# Altium schematic sheet Power_Supply2.SchDoc(Power_Supply2)
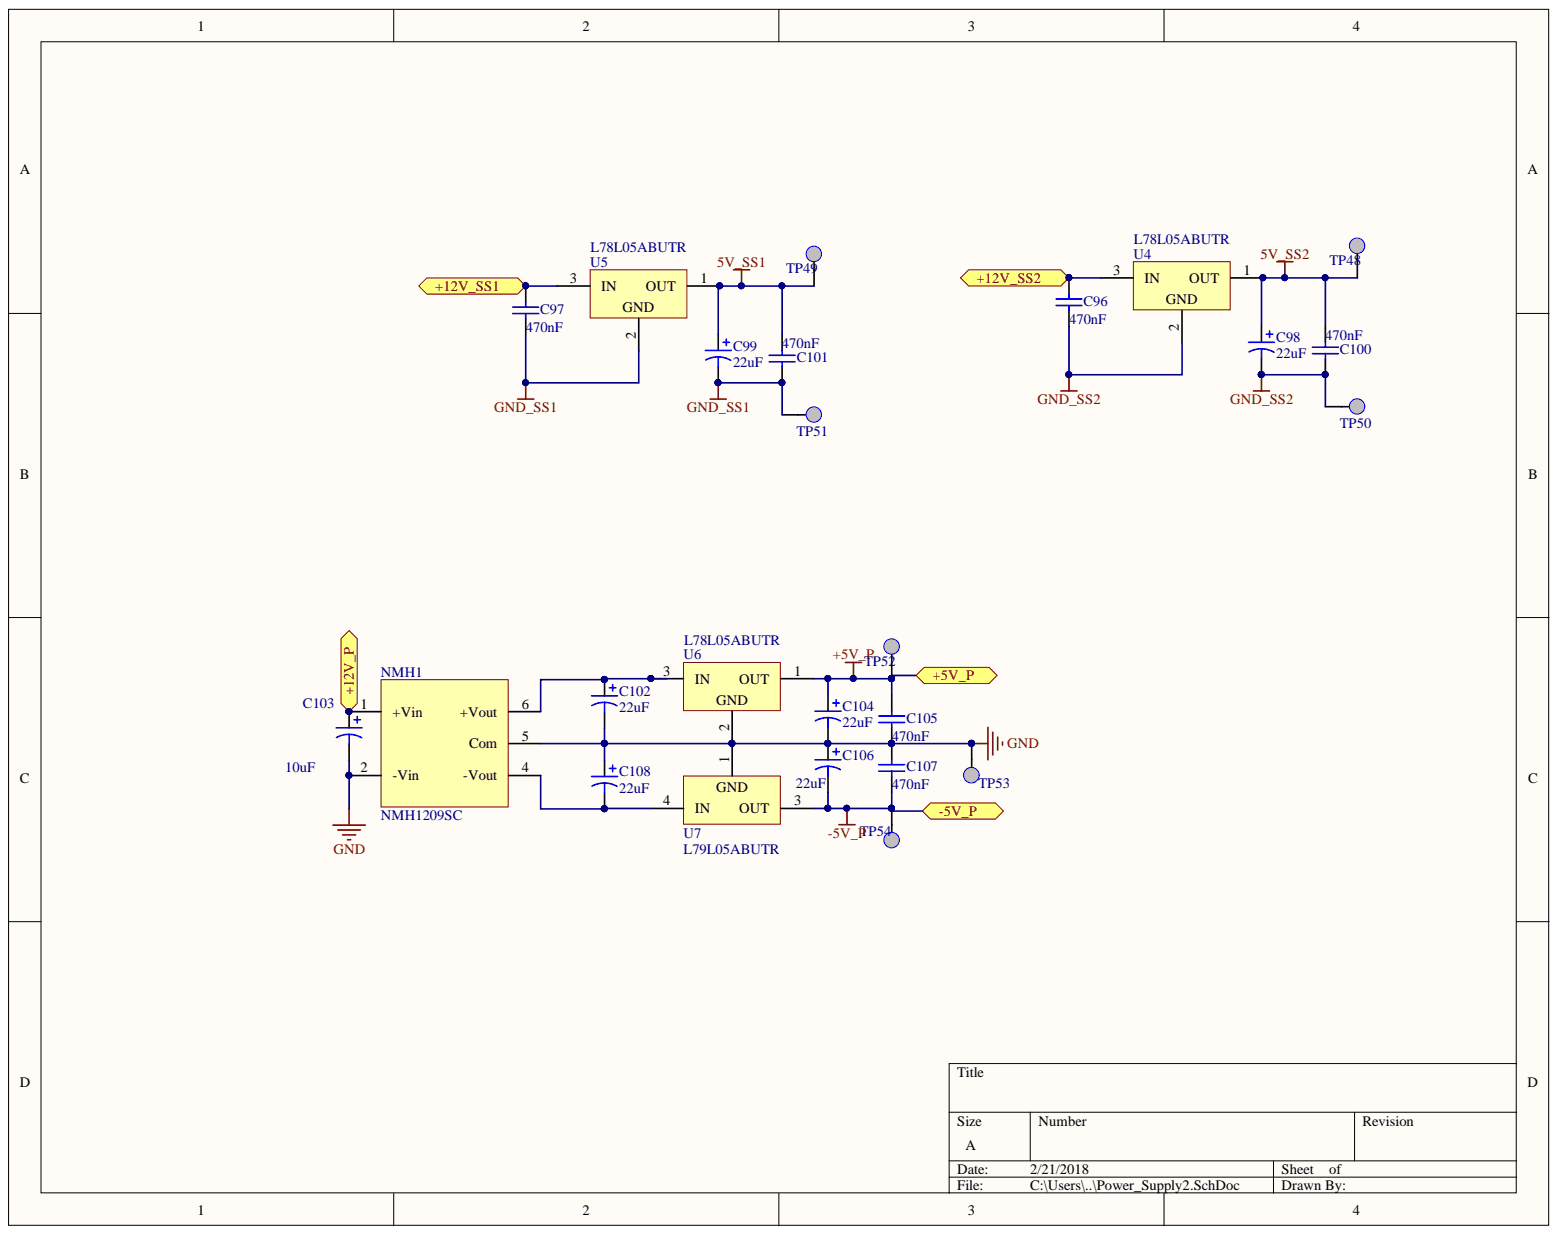
[diagram p0: Power_Supply2.SchDoc]

## Parts
C96  value 470nF  [Capacitor 0805]  (hidden comment: Cap)
C97  value 470nF  [Capacitor 0805]  (hidden comment: Cap)
C98  value 22uF  [Cap Tantal 3216]  (hidden comment: Tantalium/T520A226M006ATE090)
C99  value 22uF  [Cap Tantal 3216]  (hidden comment: Tantalium/T520A226M006ATE090)
C100  value 470nF  [Capacitor 0805]  (hidden comment: Cap)
C101  value 470nF  [Capacitor 0805]  (hidden comment: Cap)
C102  value 22uF  [Cap tantal 22u-25V]  (hidden comment: Tantalium)
C103  value 10uF  [CAP-10u-16V]  (hidden comment: electrolytic)
C104  value 22uF  [Cap Tantal 3216]  (hidden comment: Tantalium/T520A226M006ATE090)
C105  value 470nF  [Capacitor 0805]  (hidden comment: Cap)
C106  value 22uF  [Cap Tantal 3216]  (hidden comment: Tantalium/T520A226M006ATE090)
C107  value 470nF  [Capacitor 0805]  (hidden comment: Cap)
C108  value 22uF  [Cap tantal 22u-25V]  (hidden comment: Tantalium)
NMH1  NMH1209SC  [NMH1209SC]
TP48  Test point  [Test Point]  (value not drawn)
TP49  Test point  [Test Point]  (value not drawn)
TP50  Test point  [Test Point]  (value not drawn)
TP51  Test point  [Test Point]  (value not drawn)
TP52  Test point  [Test Point]  (value not drawn)
TP53  Test point  [Test Point]  (value not drawn)
TP54  Test point  [Test Point]  (value not drawn)
U4  L78L05ABUTR  [Reg SOT-89 +5]
U5  L78L05ABUTR  [Reg SOT-89 +5]
U6  L78L05ABUTR  [Reg SOT-89 +5]
U7  L79L05ABUTR  [Reg SOT-89]

## Ports
+5V_P, +12V_P, +12V_SS1, +12V_SS2, -5V_P

## Nets
5V_SS1: C99.1 C101.2 TP49.1 U5.1
5V_SS2: C98.1 C100.2 TP48.1 U4.1
GND: C102.2 C103.2 C104.2 C105.1 C106.1 C107.2 C108.1 NMH1.2(-Vin) NMH1.5(Com) TP53.1 U6.2 U7.1
GND_SS1: C97.1 C99.2 C101.1 TP51.1 U5.2
GND_SS2: C96.1 C98.2 C100.1 TP50.1 U4.2
+5V_P: C104.1 C105.2 TP52.1 U6.1 ; port +5V_P
+12V_P: C103.1 NMH1.1(+Vin) ; port +12V_P
+12V_SS1: C97.2 U5.3 ; port +12V_SS1
+12V_SS2: C96.2 U4.3 ; port +12V_SS2
-5V_P: C106.2 C107.1 TP54.1 U7.3 ; port -5V_P
NetC102_1: C102.1 NMH1.6(+Vout) U6.3
NetC108_2: C108.2 NMH1.4(-Vout) U7.4

## Library data of the parts
C96 C97 C100 C101 C105 C107 = Cap: Capacitor; Simulation: CAP; Signal Integrity: Cap; Published: 8-Jun-2000; PackageReference: RAD-0.3; Library Name: Miscellaneous Devices.IntLib; LatestRevisionNote: Re-released for DXP Platform.; LatestRevisionDate: 17-Jul-2002; Component Kind: Standard; Publisher: Altium Limited
C98 C99 C102-C104 C106 C108 = Cap Pol1: Polarized Capacitor (Radial); Simulation: CAP; Signal Integrity: Cap Pol; Published: 8-Jun-2000; PackageReference: RB7.6-15; Library Name: Miscellaneous Devices.IntLib; LatestRevisionNote: Re-released for DXP Platform.; LatestRevisionDate: 17-Jul-2002; Component Kind: Standard; Publisher: Altium Limited
NMH1 = NMH----SC_1: Library Name: control Board.SchLib; Component Kind: Standard
U4-U6 = L78L05ABZ: Positive Voltage Regulator; Published: 24-Aug-2001; PackageReference: TO92; PackageDescription: TO, Flat Index; 3 In-Line, Axial Leads; Body Dia. 4.8mm; Leads 0.51 x 0.51 mm (max); Library Name: ST Power Mgt Voltage Regulator.IntLib; LatestRevisionNote: Re-released for DXP Platform.; LatestRevisionDate: 17-Jul-2002; DatasheetVersion: Feb-1999; ComponentLink1URL: http://www.st.com/; ComponentLink1Description: Manufacturer Link; Component Kind: Standard; Publisher: Altium Limited
U7 = µA79M05CKTPR: Negative-Voltage Regulator; Note: Pin 2 is electrically connected to pin4, and not drawn.; Published: 15-Apr-2002; PackageVersion: Jan-2001; PackageReference: KTP02; PackageDescription: PowerFLEX (D-PAK/TO-252-AA); 3 Leads (1 Cropped); Body 9.7 (inc. leads) x 6.2 mm (LxW max); Library Name: TI Power Mgt Voltage Regulator.IntLib; DatasheetVersion: Apr-2000; ComponentLink2URL: http://www-s.ti.com/sc/ds/ua79m05.pdf; ComponentLink2Description: Datasheet; ComponentLink1URL: http://www.ti.com/; ComponentLink1Description: Manufacturer Link; Component Kind: Standard; Code_JEDEC: TO-252; Publisher: Altium Limited
TP48-TP54 = Test point: Library Name: CUK1.SchLib; Component Kind: Standard
